# Altium schematic sheet MCU_A2V3.SchDoc
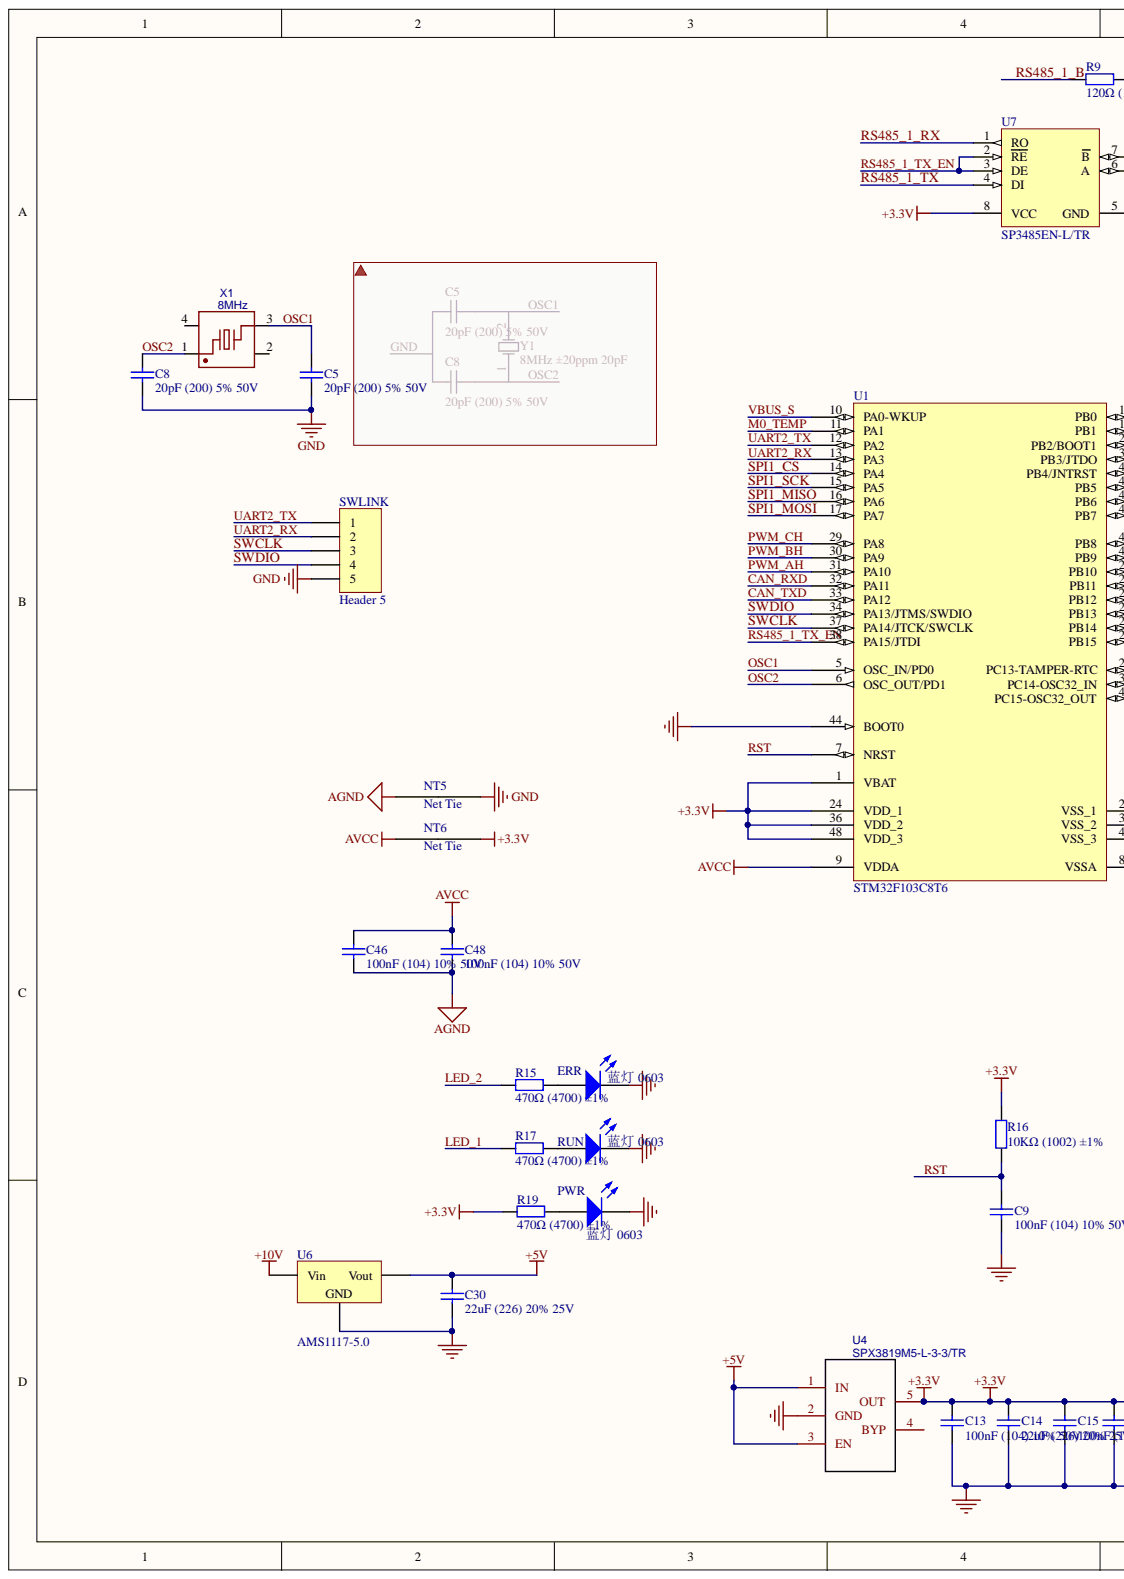
[diagram p0: MCU_A2V3.SchDoc, left]
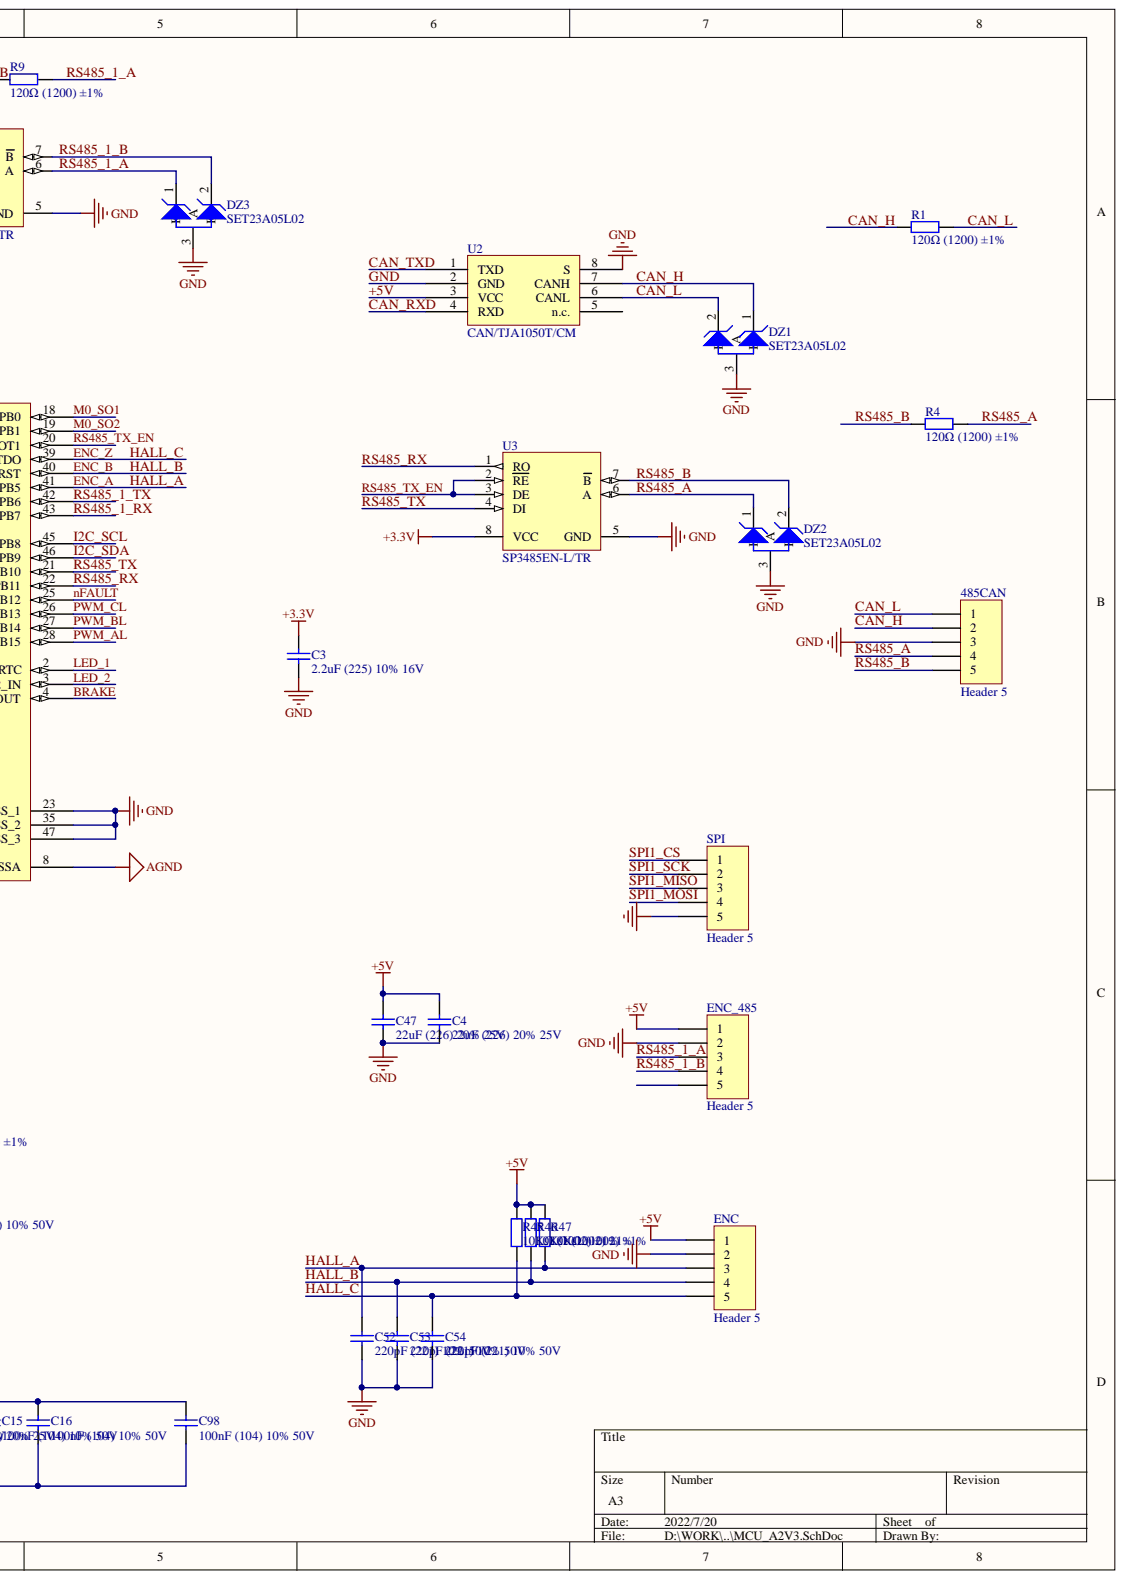
[diagram p1: MCU_A2V3.SchDoc, right]

## Parts
485CAN  Header 5  [GH 1.25 -5p-90]
C3  2.2uF (225) 10% 16V  [0603_C]
C4  22uF (226) 20% 25V  [0805_C]
C5  20pF (200) 5% 50V  [0603_C]
C8  20pF (200) 5% 50V  [0603_C]
C9  100nF (104) 10% 50V  [0603_C]
C13  100nF (104) 10% 50V  [0603_C]
C14  22uF (226) 20% 25V  [0805_C]
C15  100nF (104) 10% 50V  [0603_C]
C16  100nF (104) 10% 50V  [0603_C]
C30  22uF (226) 20% 25V  [0805_C]
C46  100nF (104) 10% 50V  [0603_C]
C47  22uF (226) 20% 25V  [0805_C]
C48  100nF (104) 10% 50V  [0603_C]
C52  220pF (221) 10% 50V  [0603_C]
C53  220pF (221) 10% 50V  [0603_C]
C54  220pF (221) 10% 50V  [0603_C]
C98  100nF (104) 10% 50V  [0603_C]
DZ1  SET23A05L02  [SOT-23L]
DZ2  SET23A05L02  [SOT-23L]
DZ3  SET23A05L02  [SOT-23L]
ENC  Header 5  [GH 1.25 -5p-90]
ENC_485  Header 5  [GH 1.25 -5p-90]
ERR  蓝灯 0603  Package: 0603  [0603_LED_S1]
NT5  0.25mm  [NetTie_0.25mm]  (value not drawn)
NT6  0.25mm  [NetTie_0.25mm]  (value not drawn)
PWR  蓝灯 0603  Package: 0603  [0603_LED_S1]
R1  120Ω (1200) ±1%  [0805_R]
R4  120Ω (1200) ±1%  [0805_R]
R9  120Ω (1200) ±1%  [0805_R]
R15  470Ω (4700) ±1%  [0603_R]
R16  10KΩ (1002) ±1%  [0603_R]
R17  470Ω (4700) ±1%  [0603_RT]
R19  470Ω (4700) ±1%  [0603_R]
R45  10KΩ (1002) ±1%  [0603_R]
R46  10KΩ (1002) ±1%  [0603_R]
R47  10KΩ (1002) ±1%  [0603_R]
RUN  蓝灯 0603  Package: 0603  [0603_LED_S1]
SPI  Header 5  [HDR1X5]
SWLINK  Header 5  [GH 1.25 -5p-90]
U1  STM32F103C8T6  [LQFP-48_7x7x05P]
U2  CAN/TJA1050T/CM  [SOP-8_150mil]
U3  SP3485EN-L/TR  [SOP-8_150mil]
U4  SPX3819M5-L-3-3/TR  [SOT23-5]
U6  AMS1117-5.0  [SOT89_N]
U7  SP3485EN-L/TR  [SOP-8_150mil]
X1  8MHz  [OSC-3225-4P]

## Nets
+3.3V: C3.2 C13.2 C14.2 C15.2 C16.2 C98.2 NT6.2 R16.2 R19.1 U1.1(VBAT) U1.24(VDD_1) U1.36(VDD_2) U1.48(VDD_3) U3.8(VCC) U4.5(OUT) U7.8(VCC)
+10V: U6.3
AGND: C46.1 C48.1 NT5.1 U1.8(VSSA)
AVCC: C46.2 C48.2 NT6.1 U1.9(VDDA)
+5V: C4.2 C30.2 C47.2 ENC.1 ENC_485.1 R45.2 R46.2 R47.2 U2.3(VCC) U4.1(IN) U4.3(EN) U6.2
BRAKE: U1.4(PC15-OSC32_OUT)
CAN_H: 485CAN.2 DZ1.1 R1.1 U2.7(CANH)
CAN_L: 485CAN.1 DZ1.2 R1.2 U2.6(CANL)
CAN_RXD: U1.32(PA11) U2.4(RXD)
CAN_TXD: U1.33(PA12) U2.1(TXD)
ENC_A: C52.2 ENC.3 R47.1 U1.41(PB5)
ENC_B: C53.2 ENC.4 R46.1 U1.40(PB4/JNTRST)
ENC_Z: C54.2 ENC.5 R45.1 U1.39(PB3/JTDO)
GND: 485CAN.3 C3.1 C4.1 C5.1 C8.1 C9.1 C13.1 C14.1 C15.1 C16.1 C30.1 C47.1 C52.1 C53.1 C54.1 C98.1 DZ1.3 DZ2.3 DZ3.3 ENC.2 ENC_485.2 ERR.K NT5.2 PWR.K RUN.K SPI.5 SWLINK.5 U1.23(VSS_1) U1.35(VSS_2) U1.44(BOOT0) U1.47(VSS_3) U2.2(GND) U2.8(S) U3.5(GND) U4.2(GND) U6.1 U7.5(GND)
I2C_SCL: U1.45(PB8)
I2C_SDA: U1.46(PB9)
LED_1: R17.1 U1.2(PC13-TAMPER-RTC)
LED_2: R15.1 U1.3(PC14-OSC32_IN)
M0_SO1: U1.18(PB0)
M0_SO2: U1.19(PB1)
M0_TEMP: U1.11(PA1)
NFAULT: U1.25(PB12)
OSC1: C5.2 U1.5(OSC_IN/PD0) X1.3
OSC2: C8.2 U1.6(OSC_OUT/PD1) X1.1
PWM_AH: U1.31(PA10)
PWM_AL: U1.28(PB15)
PWM_BH: U1.30(PA9)
PWM_BL: U1.27(PB14)
PWM_CH: U1.29(PA8)
PWM_CL: U1.26(PB13)
RS485_1_A: DZ3.1 ENC_485.3 R9.2 U7.6(A)
RS485_1_B: DZ3.2 ENC_485.4 R9.1 U7.7(B)
RS485_1_RX: U1.43(PB7) U7.1(RO)
RS485_1_TX: U1.42(PB6) U7.4(DI)
RS485_1_TX_EN: U1.38(PA15/JTDI) U7.2(RE) U7.3(DE)
RS485_A: 485CAN.4 DZ2.1 R4.2 U3.6(A)
RS485_B: 485CAN.5 DZ2.2 R4.1 U3.7(B)
RS485_RX: U1.22(PB11) U3.1(RO)
RS485_TX: U1.21(PB10) U3.4(DI)
RS485_TX_EN: U1.20(PB2/BOOT1) U3.2(RE) U3.3(DE)
RST: C9.2 R16.1 U1.7(NRST)
SPI1_CS: SPI.1 U1.14(PA4)
SPI1_MISO: SPI.3 U1.16(PA6)
SPI1_MOSI: SPI.4 U1.17(PA7)
SPI1_SCK: SPI.2 U1.15(PA5)
SWCLK: SWLINK.3 U1.37(PA14/JTCK/SWCLK)
SWDIO: SWLINK.4 U1.34(PA13/JTMS/SWDIO)
UART2_RX: SWLINK.2 U1.13(PA3)
UART2_TX: SWLINK.1 U1.12(PA2)
VBUS_S: U1.10(PA0-WKUP)
NetERR_A: ERR.A R15.2
NetPWR_A: PWR.A R19.2
NetR17_2: R17.2 RUN.A

Not connected: ENC_485 5; U2 5(n.c.); U4 4(BYP); X1 2 4

## Library data of the parts
485CAN ENC ENC_485 SPI SWLINK = Header 5: Header, 5-Pin; Component Kind: Standard; LatestRevisionDate: 17-Jul-2002; LatestRevisionNote: Re-released for DXP Platform.; Library Name: Miscellaneous Connectors.IntLib; Signal Integrity: Connector; Publisher: Altium Limited
C3 = 0603 2.2uF (225) 10% 16V: 贴片电容; Component Kind: Standard; ComponentLink1Description: 供应商链接; ComponentLink1URL: http://www.szlcsc.com/product/details_24366.html; Library Name: Cap.SchLib; Package: 0603; Supplier: LC; SuppliersPartNumber: C23630
C4 C14 C30 C47 = 0805 22uF (226) 20% 25V: 贴片电容; Component Kind: Standard; ComponentLink1Description: 供应商链接; ComponentLink1URL: http://www.szlcsc.com/product/details_46786.html; Library Name: Cap.SchLib; Package: 0805; Supplier: LC; SuppliersPartNumber: C45783
C5 C8 = 0603 20pF (200) 5% 50V: 贴片电容; Component Kind: Standard; ComponentLink1Description: 供应商链接; ComponentLink1URL: http://www.szlcsc.com/product/details_2000.html; Library Name: Cap.SchLib; Package: 0603; Supplier: LC; SuppliersPartNumber: C1648
C9 C13 C15 C16 C46 C48 C98 = 0603 100nF (104) 10% 50V: 贴片电容; Component Kind: Standard; ComponentLink1Description: 供应商链接; ComponentLink1URL: http://www.szlcsc.com/product/details_15331.html; Library Name: Cap.SchLib; Package: 0603; Supplier: LC; SuppliersPartNumber: C14663
C52-C54 = 0603 220pF (221) 10% 50V: 贴片电容; Component Kind: Standard; ComponentLink1Description: 供应商链接; ComponentLink1URL: http://www.szlcsc.com/product/details_1955.html; Library Name: Cap.SchLib; Package: 0603; Supplier: LC; SuppliersPartNumber: C1603
DZ1-DZ3 = PSOT15C: ESD二极管 5V,/5uA/SOT-23 Brightking SET23A05L02; LH: 7.2.05.0092; U: DZ; Component Kind: Standard; Library Name: 2M880C_1.SCHLIB; Manufactor Part Number: SET23A05L02; Signal Integrity: D Zener; Simulation: ZENER
ERR PWR RUN = 0603 蓝灯: 发光二极管; Component Kind: Standard; ComponentLink1Description: 供应商链接; ComponentLink1URL: http://www.szlcsc.com/product/details_73150.html; Library Name: LED.SchLib; Supplier: LC; SuppliersPartNumber: C72041
NT5 NT6 = NetTie_0.25mm: Component Kind: Net Tie; Library Name: CustomComponents.SchLib
R1 R4 R9 = 0805 120Ω (1200) 1%: 贴片电阻; Component Kind: Standard; ComponentLink1Description: 供应商链接; ComponentLink1URL: http://www.szlcsc.com/product/details_18125.html; Library Name: RES.SchLib; Package: 0805; Supplier: LC; SuppliersPartNumber: C17437
R15 R17 R19 = 0603 470Ω (4700) 1%: 贴片电阻; Component Kind: Standard; ComponentLink1Description: 供应商链接; ComponentLink1URL: http://www.szlcsc.com/product/details_23906.html; Library Name: RES.SchLib; Package: 0603; Supplier: LC; SuppliersPartNumber: C23179
R16 R45-R47 = 0603 10KΩ (1002) 1%: 贴片电阻; Component Kind: Standard; ComponentLink1Description: 供应商链接; ComponentLink1URL: http://www.szlcsc.com/product/details_26547.html; Library Name: RES.SchLib; Package: 0603; Supplier: LC; SuppliersPartNumber: C25804
U1 = STM32F103C8T6: STM32 ARM-based 32-bit MCU with 64 Kbytes Flash, 48-pin LQFP, Industrial Temperature; Component Kind: Standard; Library Name: ST Microcontroller 32-Bit STM32 SCH.lib
U2 = CAN/TJA1050T/CM_[C6952]: CAN; Component Kind: Standard; ComponentLink1Description: 供应商链接; ComponentLink1URL: http://www.szlcsc.com/product/details_7424.html; Library Name: IC.SchLib; Package: SOIC-8_150mil; Supplier: LC; SuppliersPartNumber: C6952
U3 U7 = SP3485EN-L/TR_[C8963]: RS-485/RS-422; Component Kind: Standard; ComponentLink1Description: 供应商链接; ComponentLink1URL: http://www.szlcsc.com/product/details_9482.html; Library Name: IC.SchLib; Package: SOIC-8; Supplier: LC; SuppliersPartNumber: C8963
U4 = SPX3819M5-L-3-3/TR: BOM_Manufacturer: EXAR; BOM_JLCPCB Part Class: Extended Part; BOM_Manufacturer Part: SPX3819M5-L-3-3/TR; BOM_Supplier: LCSC; BOM_Supplier Part: C9055; Component Kind: Standard; spicePre: U; spiceSymbolName: SPX3819M5-L-3-3/TR
U6 = SOT-223 AMS1117-5.0: 低压差线性稳压(LDO); Component Kind: Standard; ComponentLink1Description: 供应商链接; ComponentLink1URL: http://www.szlcsc.com/product/details_6653.html; Library Name: LDO.SchLib; Package: SOT-223; Supplier: LC; SuppliersPartNumber: C6187
X1 = 8MHz: BOM_Manufacturer: YXC扬兴科技; BOM_Manufacturer Part: X32258MSB4SI; Contributor: LCSC; nameAlias: Frequency; BOM_JLCPCB Part Class: Extended Part; BOM_Supplier: LCSC; BOM_Supplier Part: C2682774; Component Kind: Standard; spicePre: X; spiceSymbolName: X32258MSB4SI
